# Altium schematic sheet Schematic_TronicPros.SchDoc
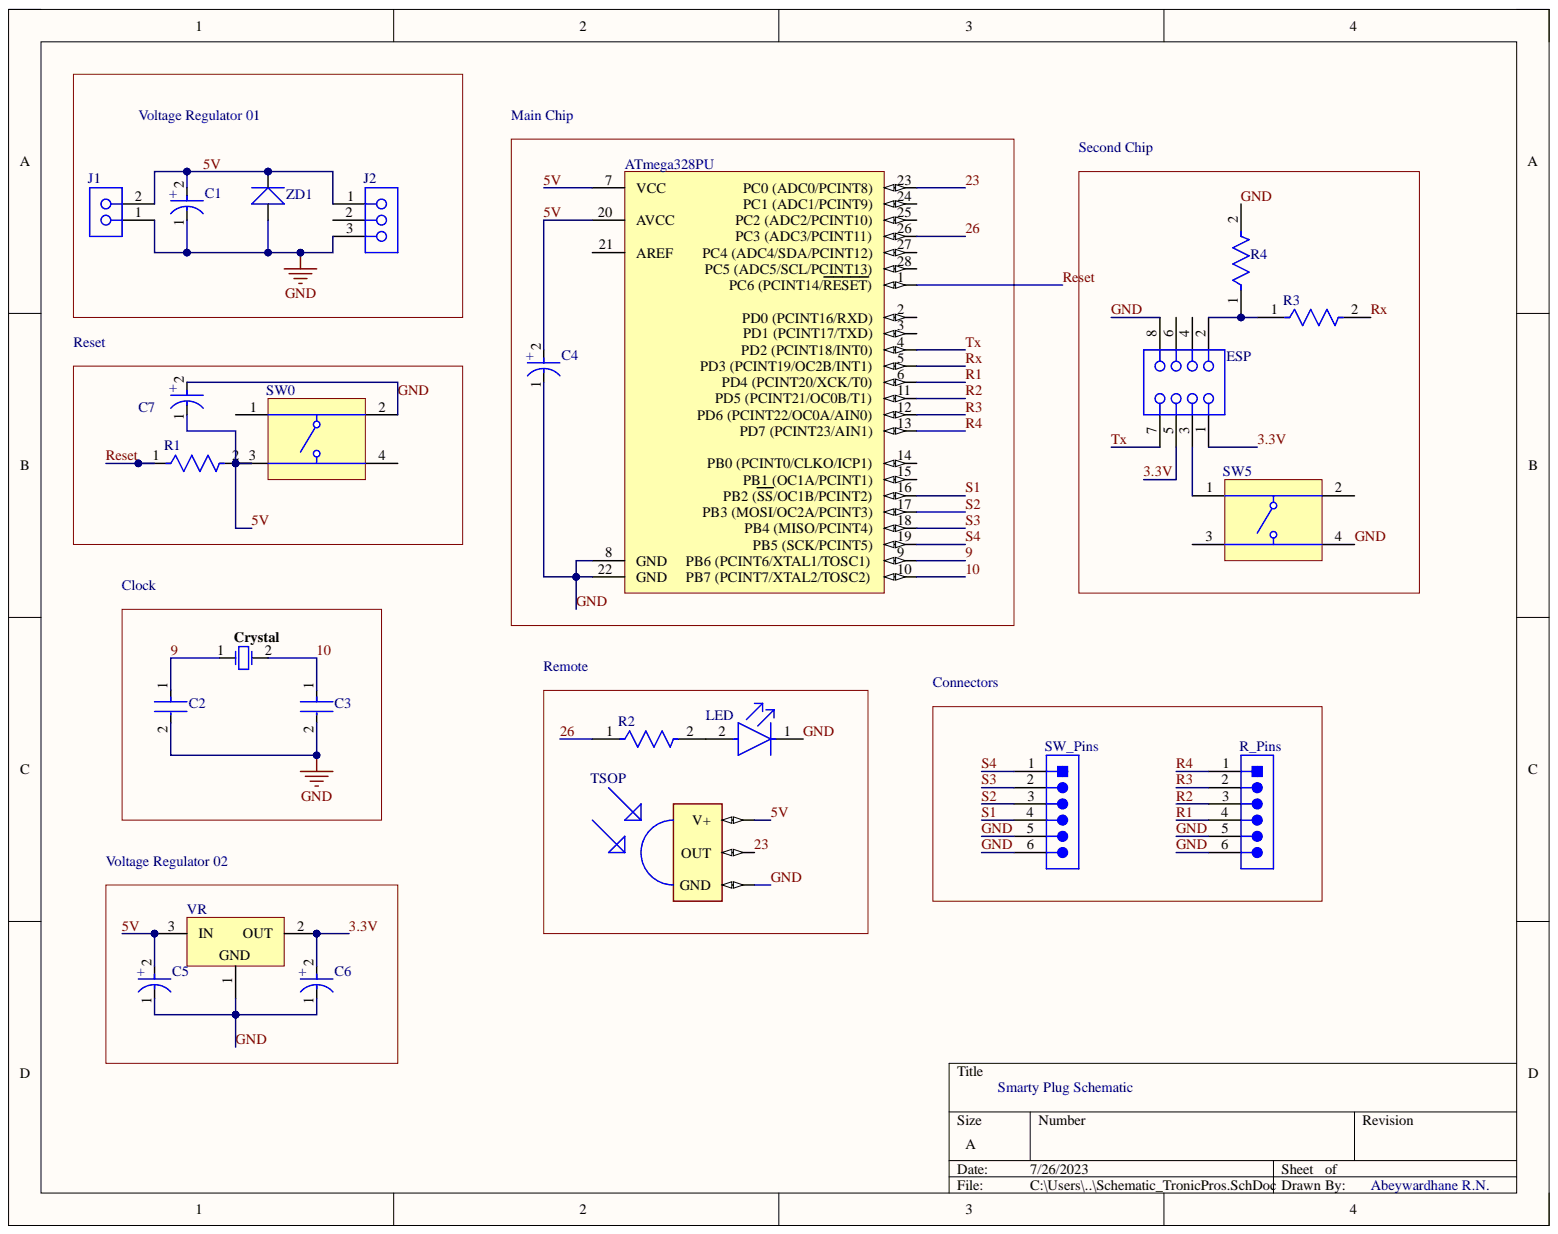
[diagram p0: Schematic_TronicPros.SchDoc]

## Parts
ATmega328PU  ATmega328-PU  [28P3]
C1  ECA1HHG100I  [FP-RAD-TH-D_5_0_5-L_11_1-MFG]  (value not drawn)
C2  RCE5C1H101J0K1H03B  [FP-RCE0K1-MFG]  (value not drawn)
C3  RCE5C1H101J0K1H03B  [FP-RCE0K1-MFG]  (value not drawn)
C4  ECA1HHG100I  [FP-RAD-TH-D_5_0_5-L_11_1-MFG]  (value not drawn)
C5  ECA1HHG100I  [FP-RAD-TH-D_5_0_5-L_11_1-MFG]  (value not drawn)
C6  ECA1HHG100I  [FP-RAD-TH-D_5_0_5-L_11_1-MFG]  (value not drawn)
C7  ECA1HHG100I  [FP-RAD-TH-D_5_0_5-L_11_1-MFG]  (value not drawn)
Crystal  FOXSLF/120-20  [FOX-FOXSLF-2_V]  (value not drawn)
ESP  SSW-104-02-G-D  [SMTC-SSW-104-02-X-D]  (value not drawn)
J1  SSW-102-02-T-S  [SMTC-SSW-102-02-X-S]  (value not drawn)
J2  SLW-103-01-T-S  [SMTC-SLW-103-01-X-S]  (value not drawn)
LED  SFH 4550  [FP-SFH_4550-MFG]  (value not drawn)
R1  MBB02070C1002FCT00  [FP-MBB0207-MFG]  (value not drawn)
R2  MBB02070C1002FCT00  [FP-MBB0207-MFG]  (value not drawn)
R3  MBB02070C1002FCT00  [FP-MBB0207-MFG]  (value not drawn)
R4  MBB02070C1002FCT00  [FP-MBB0207-MFG]  (value not drawn)
R_Pins  22-23-2061  [MOLX-22-23-2061_V]  (value not drawn)
SW0  1825910-6  [TECO-1825910-6_V]  (value not drawn)
SW5  1825910-6  [TECO-1825910-6_V]  (value not drawn)
SW_Pins  22-23-2061  [MOLX-22-23-2061_V]  (value not drawn)
TSOP  TSOP1838  [TSOP18XX]  (value not drawn)
VR  LM1117T-3.3  [T03B]  (value not drawn)
ZD1  DIP-DIODE-ZENER-3.6V-500MW(DO-35)  [DO-35]  (value not drawn)

## Nets
3.3V: C6.2 ESP.1 ESP.5 VR.2
5V: ATmega328PU.7(VCC) ATmega328PU.20(AVCC) C1.2 C4.2 C5.2 C7.1 J1.2 J2.1 R1.2 SW0.3 TSOP.VCC VR.3 ZD1.C
9: ATmega328PU.9 C2.1 Crystal.1
10: ATmega328PU.10 C3.1 Crystal.2
23: ATmega328PU.23(PC0_(ADC0/PCINT8)) TSOP.OUT
26: ATmega328PU.26(PC3_(ADC3/PCINT11)) R2.1
~{}: ATmega328PU.1(PC6_(PCINT14/RESET)) R1.1
GND: ATmega328PU.8(GND) ATmega328PU.22(GND) C1.1 C2.2 C3.2 C4.1 C5.1 C6.1 C7.2 ESP.8 J1.1 J2.3 LED.1 R4.2 R_Pins.5 R_Pins.6 SW0.2 SW5.4 SW_Pins.5 SW_Pins.6 TSOP.GND VR.1 ZD1.A
R1: ATmega328PU.6(PD4_(PCINT20/XCK/T0)) R_Pins.4
R2: ATmega328PU.11(PD5_(PCINT21/OC0B/T1)) R_Pins.3
R3: ATmega328PU.12(PD6_(PCINT22/OC0A/AIN0)) R_Pins.2
R4: ATmega328PU.13(PD7_(PCINT23/AIN1)) R_Pins.1
Rx: ATmega328PU.5(PD3_(PCINT19/OC2B/INT1)) R3.2
S1: ATmega328PU.16(PB2_(SS/OC1B/PCINT2)) SW_Pins.4
S2: ATmega328PU.17(PB3_(MOSI/OC2A/PCINT3)) SW_Pins.3
S3: ATmega328PU.18(PB4_(MISO/PCINT4)) SW_Pins.2
S4: ATmega328PU.19(PB5_(SCK/PCINT5)) SW_Pins.1
Tx: ATmega328PU.4(PD2_(PCINT18/INT0)) ESP.7
NetESP_2: ESP.2 R3.1 R4.1
NetESP_3: ESP.3 SW5.1
NetLED_2: LED.2 R2.2

Not connected: ATmega328PU 2(PD0_(PCINT16/RXD)) 3(PD1_(PCINT17/TXD)) 14(PB0_(PCINT0/CLKO/ICP1)) 15(PB1_(OC1A/PCINT1)) 21(AREF) 24(PC1_(ADC1/PCINT9)) 25 27 28(PC5_(ADC5/SCL/PCINT13)); ESP 4 6; J2 2; SW0 1 4; SW5 2 3

## Library data of the parts
ATmega328PU = CMP-0095-00015-2: 8-bit AVR Microcontroller, 32KB Flash, 1KB EEPROM, 2KB SRAM, 28-pin PDIP, Industrial Grade (-40°C to 85°C); Component Kind: Standard; ComponentLink1Description: Manufacturer URL; ComponentLink1URL: http://www.atmel.com/; ComponentLink2Description: ATmega48A/48PA/88A/88PA/168A/168PA/328/328P Datasheet; ComponentLink2URL: http://www.atmel.com/dyn/resources/prod_documents/8271.pdf; ComponentLink3Description: ATmega48A/48PA/88A/88PA/168A/168PA/328/328P Summary; ComponentLink3URL: http://www.atmel.com/dyn/resources/prod_documents/8271S.pdf; DatasheetVersion: revD, May-2011; Library Name: Altium Content Vault; PackageDescription: 28-lead (0.300"/7.62mm Wide) Plastic Dual Inline Package (PDIP); PackageReference: 28P3; PackageVersion: revB, Sep-2001; RevisionNote: DatasheetVersion updated. URLs added.
C1 C4-C7 = CMP-05427-000260-1: Aluminum Electrolytic Capacitors (Radial Lead Type) AEC-Q200 Qualified 10uF ±20% 50V; Lead Diameter: 0.5mm; Lead/Base Style: Straight; Leakage Current: 3uA; Life (Hours): 1000Hour; Polarity: Polar; Ripple Current: 39mA; Capacitance: 10uF; Case/Package: Radial; Component Kind: Standard; Construction: RADIAL; Contact Plating: Tin; Diameter: 5mm; Height: 11mm; Height - Seated (Max): 0.472inch; Lead Free: Lead Free; Lead Pitch: 2.5mm; Length: 11mm; Library Name: Altium Content Vault; Max Operating Temperature: 105°C; Min Operating Temperature: -55°C; Mount: Through Hole; Package Quantity: 2000; Packaging: Ammo Pack; Radiation Hardening: No; RoHS Compliant: Yes; Series: NHG; Termination: Radial; Tolerance: 20%; Voltage Rating: 50V; Voltage Rating (DC): 50V
C2 C3 = CMP-06035-040252-1: Cap Ceramic 100pF 50V C0G ±5% Radial 5mm +125°C Automotive Bulk; Lead Diameter: 0.5mm; Lead/Base Style: Formed Leads; Capacitance: 100pF; Case/Package: Radial; Component Kind: Standard; Dielectric: C0G; Height: 3.5mm; Height - Seated (Max): 0.138inch; Lead Pitch: 0.197inch; Length: 0.142inch; Library Name: Altium Content Vault; Max Operating Temperature: 125°C; Min Operating Temperature: -55°C; Mount: Through Hole; Package Quantity: 500; Packaging: Bulk; Ratings: AEC-Q200; Resistor Type: Ceramic; Termination: Radial; Tolerance: 5%; Voltage Rating: 50V; Voltage Rating (DC): 50V; Width: 2.5mm
Crystal = CMP-1749-00004-1: Resistance Weld Thru-Hole Crystal, 12 MHz, +/-30 ppm, -20 to 70 degC, 2-Pin THD, RoHS, Bulk; Case\Package: TO-251-3; Elements: 1; Input Capacitance: 350 pF; Max Power Dissipation: 2.5 W; Rds On Max: 115 mohm; Typical Rise Time: 90 ns; Component Kind: Standard; ComponentLink1Description: Manufacturer URL; ComponentLink1URL: http://www.foxonline.com/; ComponentLink2Description: Datasheet; ComponentLink2URL: http://www.foxonline.com/pdfs/hc49slf.pdf; DatasheetVersion: Mar-15; Height: 6.1 mm; Length: 6.6 mm; Library Name: Altium Content Vault; Max Operating Temperature: 150 degC; Min Operating Temperature: -55 degC; Mount: Through Hole; Package Quantity: 70; PackageDescription: 2-Pin Through Hole Device, Body 11.35 x 4.65 mm, Pitch 4.88 mm; PackageReference: FOXSLF-2; Packaging: Rail/Tube; Pins: 3; Radiation Hardening: No; REACH SVHC: No SVHC; RoHSCompliant: true; Width: 2.3 mm
ESP = CMP-1023-00343-1: SQ Post Socket, Through-hole, Vertical, -55 to 125 degC, 2.54mm Pitch, 8-Pin, Female, RoHS; Manufacturer: Samtec; Component Kind: Standard; ComponentLink1Description: Manufacturer URL; ComponentLink1URL: http://www.samtec.com; ComponentLink2Description: Datasheet; ComponentLink2URL: http://www.samtec.com/documents/webfiles/pdf/SSW_TH.PDF; ComponentLink3Description: Package Specification; ComponentLink3URL: http://www.samtec.com/documents/webfiles/cpdf/SSW-1XX-XX-XXX-X-XX-XXX-XX-MKT.pdf; DatasheetVersion: F-214; Library Name: Altium Content Vault; Mounting Technology: Through-hole; Orientation: Vertical; PackageDescription: Assembly, Socket Strip .016 X .031 Tail; PackageReference: SSW-104-02-X-D; PackageVersion: CA, 6/1987; PartNumber: SSW-104-02-G-D; Pitch: 2.54 mm; RoHS: True; Temperature Range: -55 to 125 degC
J1 = CMP-1023-00323-1: SQ Post Socket, Through-hole, Vertical, -55 to 105 degC, 2.54 mm Pitch, 2-Pin, Female, RoHS; Manufacturer: Samtec; Pitch: 2.54 mm; Component Kind: Standard; ComponentLink1Description: Manufacturer URL; ComponentLink1URL: http://www.samtec.com; ComponentLink2Description: Datasheet; ComponentLink2URL: http://www.samtec.com/documents/webfiles/pdf/SSW_TH.PDF; ComponentLink3Description: Package Specification; ComponentLink3URL: http://www.samtec.com/documents/webfiles/cpdf/SSW-1XX-XX-XXX-X-XX-XXX-XX-MKT.pdf; DatasheetVersion: F-214; Library Name: Altium Content Vault; Mounting Technology: Through-hole; Orientation: Vertical; PackageDescription: Assembly, Socket Strip .016 X .031 Tail; PackageReference: SSW-102-02-X-S; PackageVersion: CA, 6/1987; PartNumber: SSW-102-02-T-S; RoHS: True; Temperature Range: -55 to 105 degC
J2 = CMP-1023-00129-1: Low Profile Socket Strips, Through-hole, Vertical, -55 to 105 degC, 2.54 mm Pitch, 3-Pin, Female, RoHS; Manufacturer: Samtec; Pitch: 2.54 mm; Component Kind: Standard; ComponentLink1Description: Manufacturer URL; ComponentLink1URL: http://www.samtec.com; ComponentLink2Description: Datasheet; ComponentLink2URL: http://www.samtec.com/documents/webfiles/pdf/SLW.PDF; ComponentLink3Description: Package Specification; ComponentLink3URL: http://www.samtec.com/documents/webfiles/cpdf/SLW-1XX-01-X-X-MKT.pdf; DatasheetVersion: F-214; Library Name: Altium Content Vault; Mounting Technology: Through-hole; Orientation: Vertical; PackageDescription: Low Profile Socket Strip; PackageReference: SLW-103-01-X-S; PackageVersion: X, 9/1987; PartNumber: SLW-103-01-T-S; RoHS: True; Temperature Range: -55 to 105 degC
LED = CMP-62016-000011-1: EMITTER IR 860NM 100MA RADIAL; Manufacturer: Osram Opto; Forward Voltage: 1.5V; Led Orientation: Straight; Reverse Voltage: 5V; Viewing Angle: 6°; Automotive: No; Category: Diode; Colour: Infrared; Component Kind: Standard; ComponentLink2Description: Datasheet; ComponentLink2URL: https://dammedia.osram.info/media/resource/hires/osram-dam-5580407/SFH%204550_EN.pdf; Device Class L1: Optoelectronics; Device Class L2: LEDs; Device Class L3: unset; Digikey Description: EMITTER IR 860NM 100MA RADIAL; Forward Current: 100mA; Lead Free: Yes; Library Name: Altium Content Vault; Manufacturer Part Number: SFH 4550; Mouser Description: Infrared Emitters - High Power Infrared 850 nm HALF ANGLE +/-3DEG; Octopart: https://octopart.com/sfh+4550-osram+opto-55410894; Package: SFH 4550; Peak Emmision Wavelength: 860nm; RoHS: TRUE; Temperature: -40°C to +100°C; Temperature Range High: +100°C; Temperature Range Low: -40°C
R1-R4 = CMP-02408-000035-1: RES 10K OHM 0.6W 1% AXIAL; Max Power Dissipation: 600mW; Resistance: 10kR; Temperature Coefficient: 50ppm/°C; Voltage Rating: 300V; Case/Package: Conformal; Component Kind: Standard; Construction: Cylindrical; Contact Plating: Tin; Diameter: 2.5mm; Features: Automotive AEC-Q200; Lead Free: Lead Free; Length: 6.5mm; Library Name: Altium Content Vault; Max Operating Temperature: 155°C; Military Standard: Not; Min Operating Temperature: -55°C; Number of Terminations: 2; Packaging: Tape and Reel; Power Rating: 600mW; Radiation Hardening: No; Resistance Tolerance: 1%; Resistor Type: Metal Film; RoHS Compliant: Yes; Series: MBB 0207 - Professional; Technology: THIN FILM; Termination: Axial; Tolerance: 1%; Voltage Rating (DC): 300V
R_Pins SW_Pins = CMP-2000-05536-1: Male Header, Pitch 2.54 mm, 1 x 6 Position, Height 10.67 mm, Tail Length 3.56 mm, 0 to 70 degC, RoHS, Bulk; Brand: Molex; Current Rating: 4A; Mounting Angle: Vertical; Number of Positions: 6; Pitch: 2.54mm; Voltage Rating: 250V; Component Kind: Standard; Contact Material: Brass; Contact Plating: Tin; Datasheet URL: https://datasheet.ciiva.com/19460/232303-19460895.pdf; Datasheet Version: Rev. P, 09/2001; Factory Pack Quantity: 1000; Housing Material: Nylon; Library Name: Altium Content Vault; Manufacturer: Molex; Manufacturer URL: http://www.molex.com/; Mounting Style: ThroughHole; Mounting Technology: ThroughHole; Number of Positions / Contacts: 6; Number of Rows: 1; Package Description: Male Header, Pitch 2.54 mm, 1 x 6 Position, Height 10.67 mm, Tail Length 3.56 mm; Package Reference: 22-23-2061; Packaging: Bulk; Product Category: .100K.K.Connectors; Product Type: Headers; RoHS: Details; Series: 6373; Termination Style: ThroughHole; Tradename: KK; Type: PinStrip
SW0 SW5 = CMP-1684-00021-1: Tact Switch, SPST-NO, 0.05 A, -35 to 85 degC, 4-Pin THD, RoHS, Bulk; Manufacturer: TE Connectivity; Actuator Color: Black; Actuator Length: 1.4mm; Actuator Material: PolybutyleneTerephthalate; Actuator Style: RoundButton; Body Orientation: Straight; Bushing Type: Unthreaded; Contact Rating @ Voltage: 0.05A@24VDC; Dielectric Strength: 500VAC; Electrical Life: 100000Cycles; Function: MomentaryContact; Illumination: N/R; Maximum Contact Resistance: 100mOhm; Maximum DC Voltage Rating: 24V; Pole Throw Configuration: SPST; Switch Configuration: OFF(ON); Base Material: N/A; Component Kind: Standard; Contact Material: CopperAlloy; Contact Plating: Silver; Datasheet URL: http://www.te.com/commerce/DocumentDelivery/DDEController?Action=showdoc&DocId=Customer+Drawing%7F1825910%7FC9%7Fpdf%7FEnglish%7FENG_CD_1825910_C9.pdf%7F1825910-6; Datasheet Version: Rev. C9; Dimension: 5.99x5mm; Family: 1825910; Housing Material: N/A; Library Name: Altium Content Vault; Manufacturer URL: http://www.te.com; Maximum AC Voltage Rating: N/A; Mechanical Life: 100000Cycles; Minimum Insulation Resistance: 100MOhm; Mounting: ThroughHole; Operating Temperature: -35to85C; Package Description: 4-Pin Through Hole Device, Body 5.99 x 5.99 mm, Pitch 6.5 mm; Package Reference: THD; Packaging: N/A; Part No: 1825910-6; Product Weight: N/A; RoHS: Yes; Seal Description: N/A; Seal Location: N/A; Terminal Type: PCPins; Thread Size: N/R
TSOP = TSOP1838: IR Receiver and Demodulator; Availability: Unavailable; Component Kind: Standard; Library Name: TSOP1838.IntLib; MP: TSOP1838; Package: None; Price: None
VR = CMP-0062-02490-2: 800mA Low-Dropout Linear Regulator, 3-pin TO-220; Manufacturer: National Semiconductor; CompType: LDO IC; PackageTopMarking: NSUZXYTTE#*LM1117T*3.3; PowerWise: N; Component Kind: Standard; ComponentLink1Description: LM1117 Datasheet; ComponentLink1URL: http://www.national.com/ds/LM/LM1117.pdf; ComponentLink2Description: LM1117 Web Page; ComponentLink2URL: http://www.national.com/pf/LM/LM1117.html; ComponentLink3Description: T03B Package Page; ComponentLink3URL: http://www.national.com/packaging/folders/t03b.html; DatasheetVersion: Apr-2006; Library Name: Altium Content Vault; PackageDescription: TO-220, Molded, 3 Lead, Straight; PackageReference: T03B; PackageVersion: revL, Jan-1992; PinCount: 3; RevisionNote: Component URLs added; RoHS: N
ZD1 = DIP-DIODE-ZENER-3.6V-500MW(DO-35): Zener Diode 3.6V 500mW Â±5% Through Hole DO-35; MF: Micro Commercial; MP: 1N5227B-TP; Availability: Unavailable; Component Kind: Standard; Library Name: DIP-DIODE-ZENER-3.6V-500MW_DO-35_.IntLib; Package: DO-35 MCC; Price: None; SnapEDA_Link: https://www.snapeda.com/parts/1N5227B-TP/Micro%20Commercial/view-part/214543/?ref=snap
